# Altium schematic sheet 018_FlashMMC.SchDoc(018_FlashMMC)
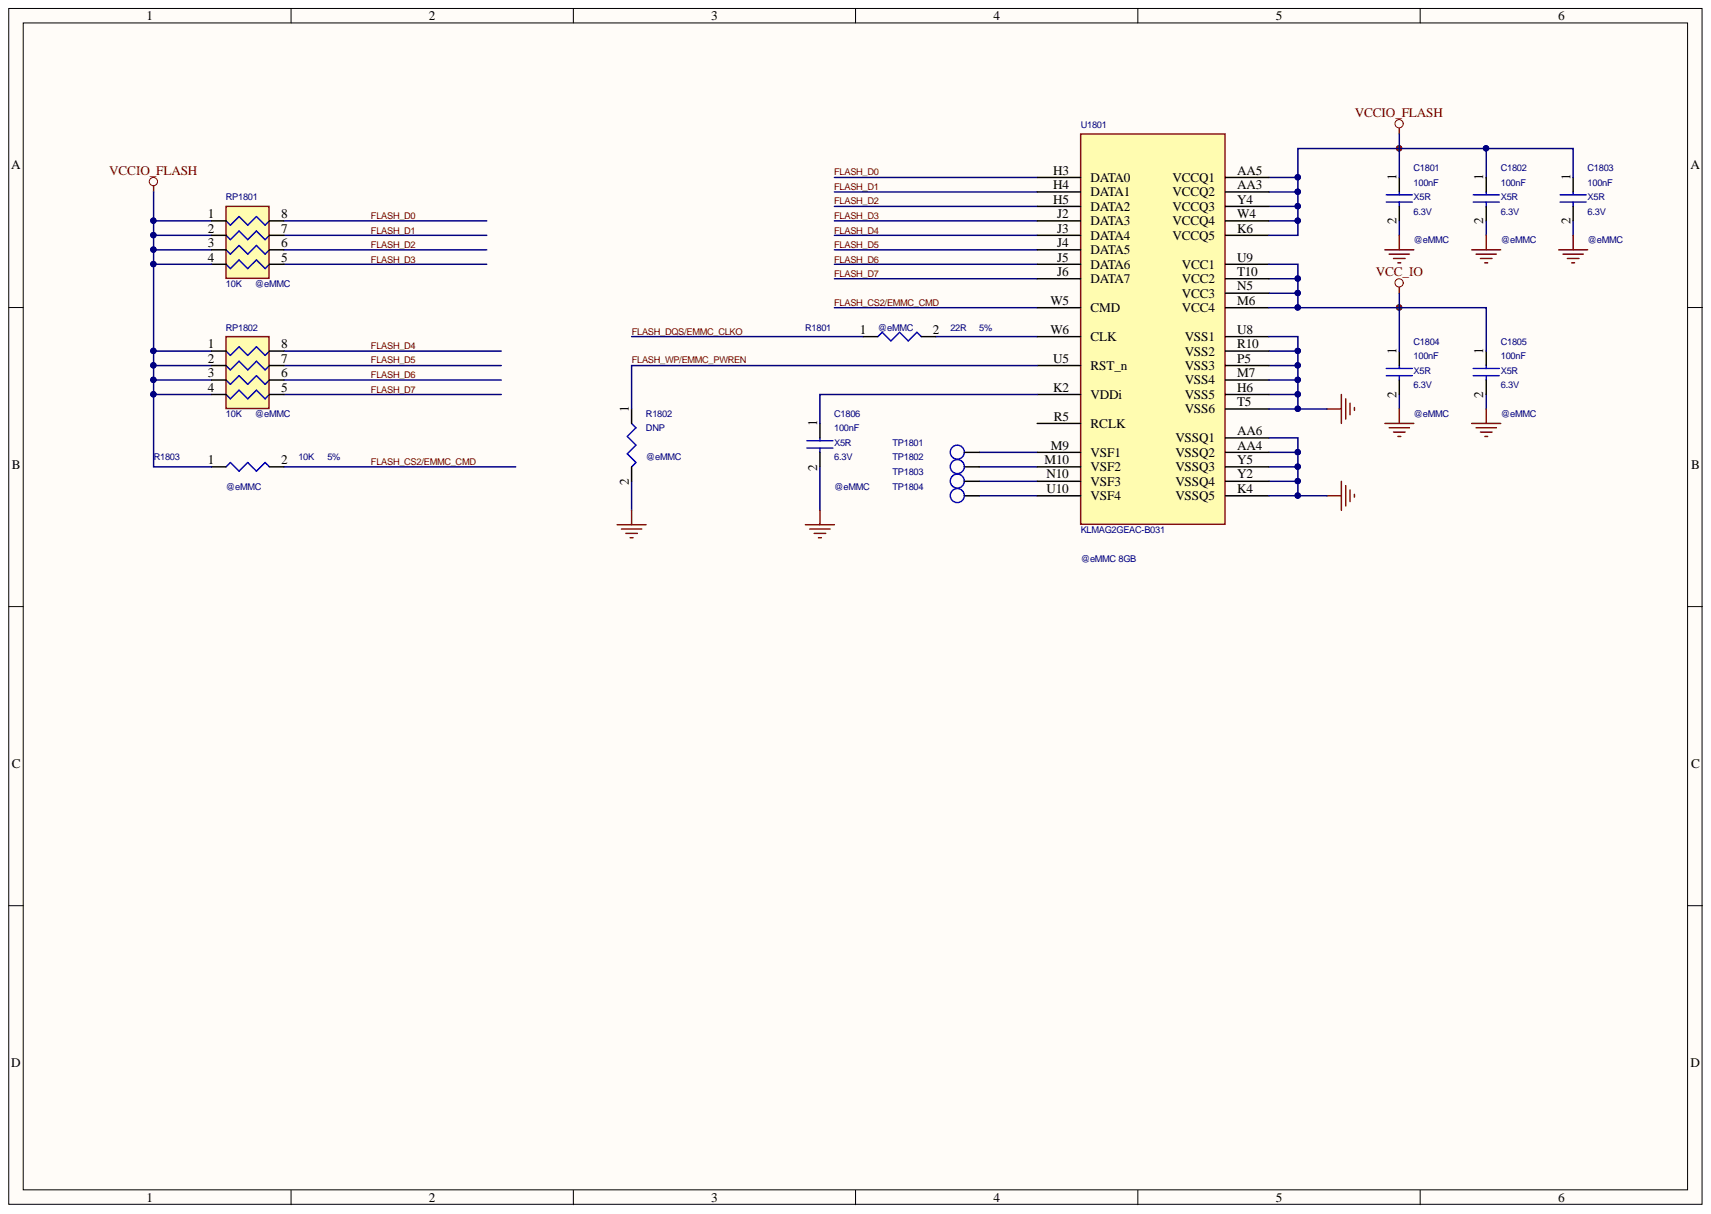
[diagram p0: 018_FlashMMC.SchDoc]

## Parts
C1801  100nF  Voltage: 6.3V  Option: @eMMC  Dielectric: X5R  [C0402]
C1802  100nF  Voltage: 6.3V  Option: @eMMC  Dielectric: X5R  [C0402]
C1803  100nF  Voltage: 6.3V  Option: @eMMC  Dielectric: X5R  [C0402]
C1804  100nF  Voltage: 6.3V  Option: @eMMC  Dielectric: X5R  [C0402]
C1805  100nF  Voltage: 6.3V  Option: @eMMC  Dielectric: X5R  [C0402]
C1806  100nF  Voltage: 6.3V  Option: @eMMC  Dielectric: X5R  [C0402]
R1801  22R  Option: @eMMC  [R0402]
R1802  DNP  Option: @eMMC  [R0402]
R1803  10K  Option: @eMMC  [R0402]
RP1801  10K  Option: @eMMC  [0603RS4]
RP1802  10K  Option: @eMMC  [0603RS4]
TP1801  TP_0.7  [TEST]  (value not drawn)
TP1802  TP_0.7  [TEST]  (value not drawn)
TP1803  TP_0.7  [TEST]  (value not drawn)
TP1804  TP_0.7  [TEST]  (value not drawn)
U1801  KLMAG2GEAC-B031  [INANA-BGA169]

## Nets
GND: C1801.2 C1802.2 C1803.2 C1804.2 C1805.2 C1806.2 R1802.2 U1801.AA4(VSSQ2) U1801.AA6(VSSQ1) U1801.H6(VSS5) U1801.K4(VSSQ5) U1801.M7(VSS4) U1801.P5(VSS3) U1801.R10(VSS2) U1801.T5(VSS6) U1801.U8(VSS1) U1801.Y2(VSSQ4) U1801.Y5(VSSQ3)
VCC_IO: C1804.1 C1805.1 U1801.M6(VCC4) U1801.N5(VCC3) U1801.T10(VCC2) U1801.U9(VCC1)
VCCIO_FLASH: C1801.1 C1802.1 C1803.1 R1803.1 RP1801.1 RP1801.2 RP1801.3 RP1801.4 RP1802.1 RP1802.2 RP1802.3 RP1802.4 U1801.AA3(VCCQ2) U1801.AA5(VCCQ1) U1801.K6(VCCQ5) U1801.W4(VCCQ4) U1801.Y4(VCCQ3)
FLASH_CS2/EMMC_CMD: R1803.2 U1801.W5(CMD)
FLASH_D0: RP1801.8 U1801.H3(DATA0)
FLASH_D1: RP1801.7 U1801.H4(DATA1)
FLASH_D2: RP1801.6 U1801.H5(DATA2)
FLASH_D3: RP1801.5 U1801.J2(DATA3)
FLASH_D4: RP1802.8 U1801.J3(DATA4)
FLASH_D5: RP1802.7 U1801.J4(DATA5)
FLASH_D6: RP1802.6 U1801.J5(DATA6)
FLASH_D7: RP1802.5 U1801.J6(DATA7)
FLASH_DQS/EMMC_CLKO: R1801.1
FLASH_WP/EMMC_PWREN: R1802.1 U1801.U5(RST_n)
NetC1806_1: C1806.1 U1801.K2(VDDi)
NetR1801_2: R1801.2 U1801.W6(CLK)
NetTP1801_1: TP1801.1 U1801.M9(VSF1)
NetTP1802_1: TP1802.1 U1801.M10(VSF2)
NetTP1803_1: TP1803.1 U1801.N10(VSF3)
NetTP1804_1: TP1804.1 U1801.U10(VSF4)

Not connected: U1801 R5(RCLK)

## Library data of the parts
C1801-C1806 = CAP NP_Dup5: cap,100.00nF,+/-10%,6.3V,X5R,C0402; Manufacturer: TDK; Tolerance: 10%; RK PN: C1005X5R0J104K050BA; Priority: A; Number: 37; Part Type: C0402; Manufacturer PN: C1005X5R0J104K050BA; Component Kind: Standard; C_Voltage: 6.3V; Created by: JJJ
R1801 = RESISTOR_Dup1: 哥棨슌훡롯量,22R,+/-5%,R0402,1/16W.; Manufacturer: YAGEO; Wattage: 1/16W; Tolerance: 5%; RK PN: RC0402JR-0722RL; Priority: A; Part Type: 哥棨슌훡롯量; Manufacturer PN: RC0402JR-0722RL; Component Kind: Standard; Created by: YTL
R1802 = RESISTOR_Dup1: Did Not Place.; Tolerance: 0%; RK PN: DNP0402; Priority: A; Part Type: DNP; Manufacturer PN: DNP0402; Component Kind: Standard; Created by: JJJ
R1803 = RESISTOR_Dup1: 哥棨슌훡롯量,10K,+/-5%,R0402,1/16W.; Manufacturer: YAGEO; Wattage: 1/16W; Tolerance: 5%; RK PN: RC0402JR-0710KL; Priority: A; Part Type: 哥棨슌훡롯量; Manufacturer PN: RC0402JR-0710KL; Component Kind: Standard; Created by: YTL
RP1801 RP1802 = RESISTOR 4PACK: 跏攪뚬꽈量,10K,5%,RP4_0408,1/16W; Manufacturer: YAGEO; RK PN: YC124-JR-0710KL; Priority: A; Wattage: 1/16W; Tolerance: 5%; Part Type: 跏攪뚬꽈量; Manufacturer PN: YC124-JR-0710KL; Component Kind: Standard; Created by: YTL
TP1801-TP1804 = TP: Test Point 0.7mm; RK PN: TESTPONIT_0R7; Priority: A; Part Type: TP; Created Date: 20140617; Component Kind: Standard; Created by: CZD
U1801 = INAND_EMMC_169: 64Gb MLC x 2,16GB,19nm,5.0,11.5 x 13; Manufacturer: Samsung?짢僑?; RK PN: eMMC; Priority: A; Option: @eMMC 8GB; Part Type: NAND_FLASH; Manufacturer PN: KLMAG2GEAC-B031; Component Kind: Standard; Created by: JJJ
